# Altium schematic sheet Braking.SchDoc
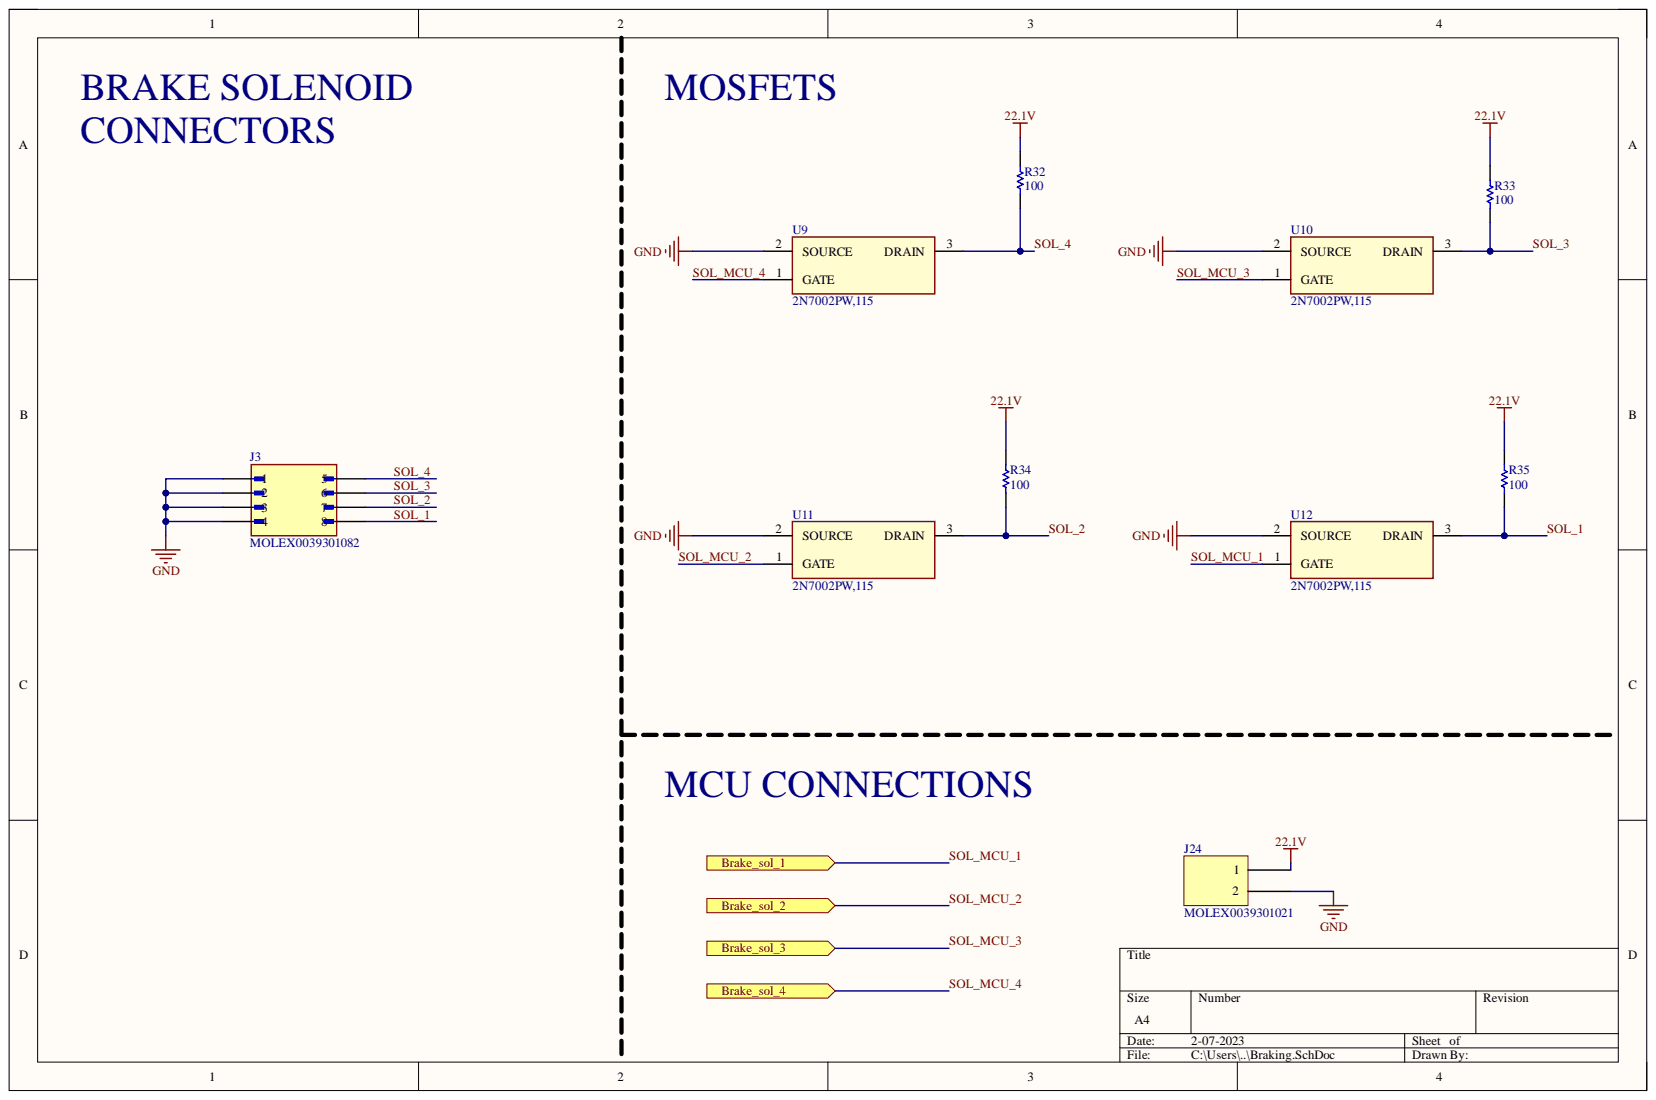
[diagram p0: Braking.SchDoc]

## Parts
J3  MOLEX0039301082  [MOLEX0039301082]
J24  MOLEX0039301021  [MOLEX0039301021]
R32  value 100  [0805 - Resistor]  (hidden comment: Resistor)
R33  value 100  [0805 - Resistor]  (hidden comment: Resistor)
R34  value 100  [0805 - Resistor]  (hidden comment: Resistor)
R35  value 100  [0805 - Resistor]  (hidden comment: Resistor)
U9  2N7002PW,115  [SOT65P210X110-3N]
U10  2N7002PW,115  [SOT65P210X110-3N]
U11  2N7002PW,115  [SOT65P210X110-3N]
U12  2N7002PW,115  [SOT65P210X110-3N]

## Ports
Brake_sol_1, Brake_sol_2, Brake_sol_3, Brake_sol_4

## Nets
22.1V: J24.1 R32.2 R33.2 R34.2 R35.2
GND: J3.1 J3.2 J3.3 J3.4 J24.2 U9.2 U10.2 U11.2 U12.2
SOL_1: J3.8 R35.1 U12.3
SOL_2: J3.7 R34.1 U11.3
SOL_3: J3.6 R33.1 U10.3
SOL_4: J3.5 R32.1 U9.3
SOL_MCU_1: U12.1 ; port Brake_sol_1
SOL_MCU_2: U11.1 ; port Brake_sol_2
SOL_MCU_3: U10.1 ; port Brake_sol_3
SOL_MCU_4: U9.1 ; port Brake_sol_4

## Library data of the parts
J3 = MOLEX0039301082: Connector Header Through Hole, Right Angle 8 position 0.165" (4.20mm); Manufacturer: Molex; Component Kind: Standard; ComponentLink1Description: Datasheet; ComponentLink1URL: https://www.molex.com/pdm_docs/sd/039301082_sd.pdf; Library Name: GeneralConnectors.SchLib; Manufacturer Part Number: 0039301082; Supplier: DigiKey; Supplier Part Number: WM21366-ND
J24 = MOLEX0039301021: Connector Header Through Hole, Right Angle 2 pin 0.165" (4.20mm); Component Kind: Standard; Library Name: GeneralConnectors.SchLib
U9-U12 = 2N7002PW,115: N-Channel 60V 310mA (Ta) 260mW (Ta) Surface Mount SOT-323; MF: Nexperia USA; Component Kind: Standard; ComponentLink1Description: Manufacturer name; ComponentLink1URL: *Nexperia USA Inc.; ComponentLink2Description: Manufacturer part number; ComponentLink2URL: PMF370XN,115; ComponentLink3Description: Supplier name; ComponentLink3URL: Digikey; ComponentLink4Description: Supplier part #; ComponentLink4URL: 1727-5422-2-ND; Digi-Key_Part_Number: 1727-4793-1-ND; Library Name: Embedded.SchLib; MP: 2N7002PW,115; Package: SOT-323 Nexperia; SnapEDA_Link: https://www.snapeda.com/parts/2N7002PW,115/Nexperia%20USA%20Inc./view-part/2152859/?ref=snap
R32-R35 = Resistor: Component Kind: Standard; Description: Resistor; Library Name: General.SchLib; Signal Integrity: Res; Simulation: RESISTOR
